# Altium schematic sheet 16 RGMII.SchDoc
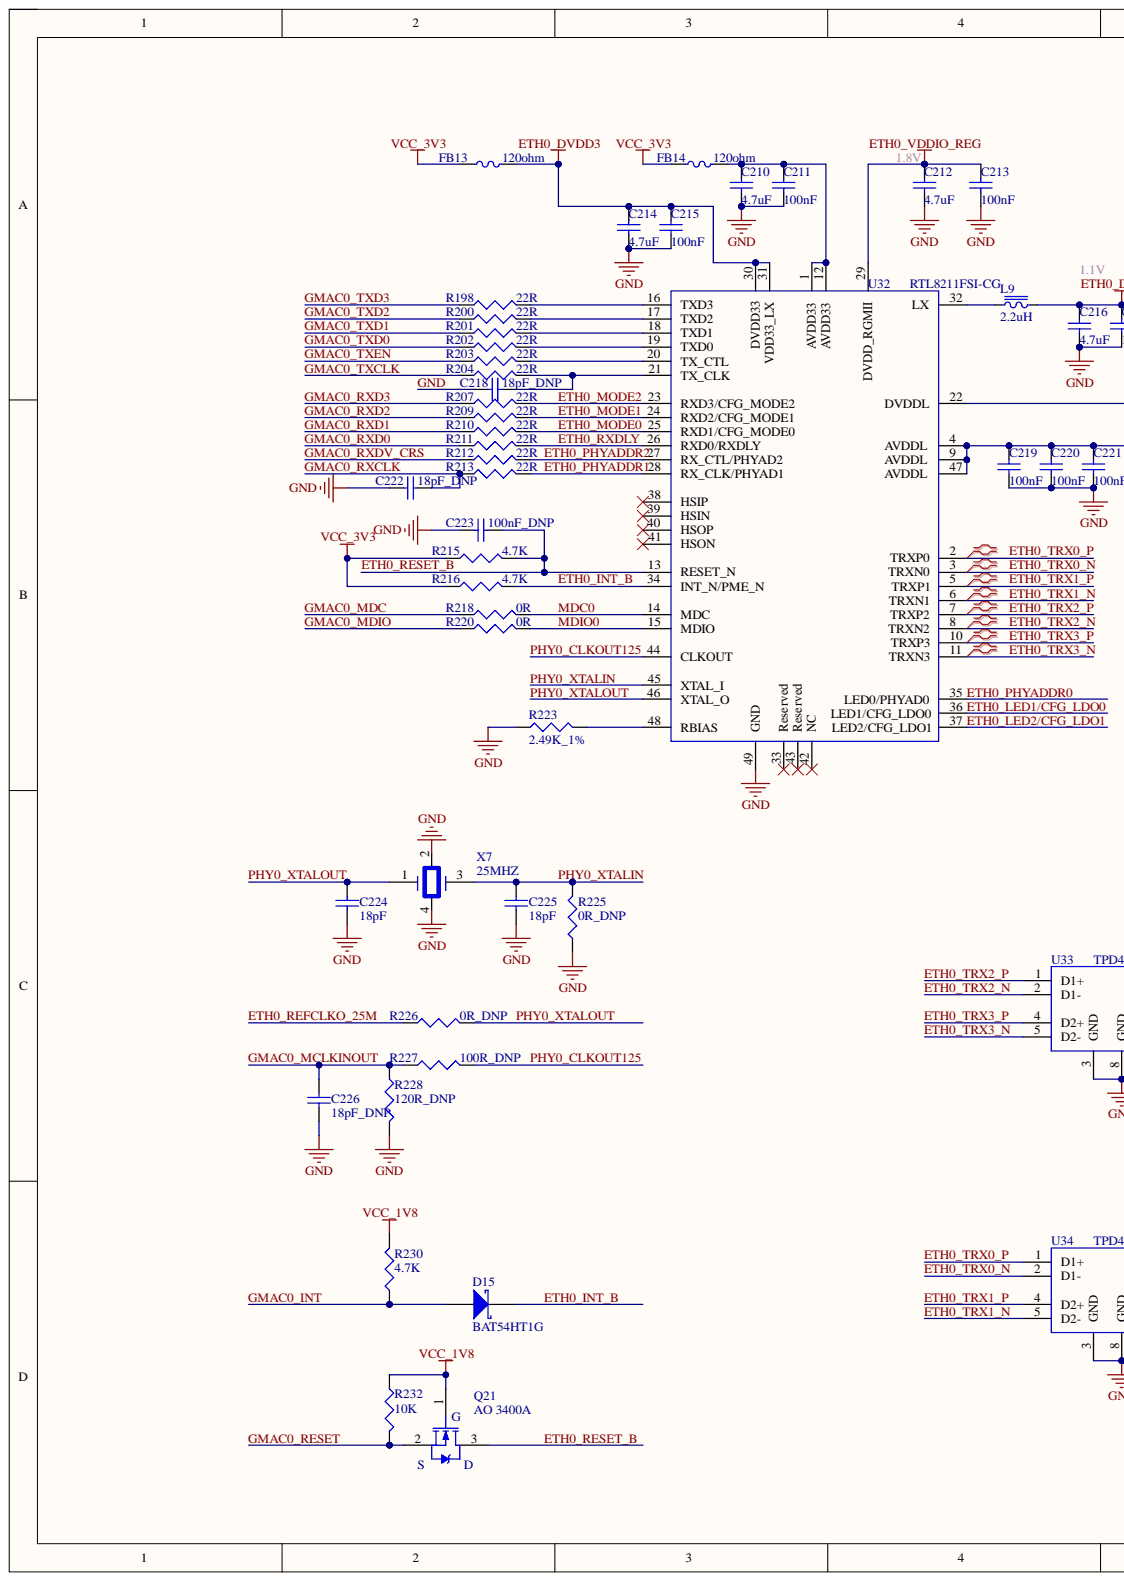
[diagram p0: 16 RGMII.SchDoc, left]
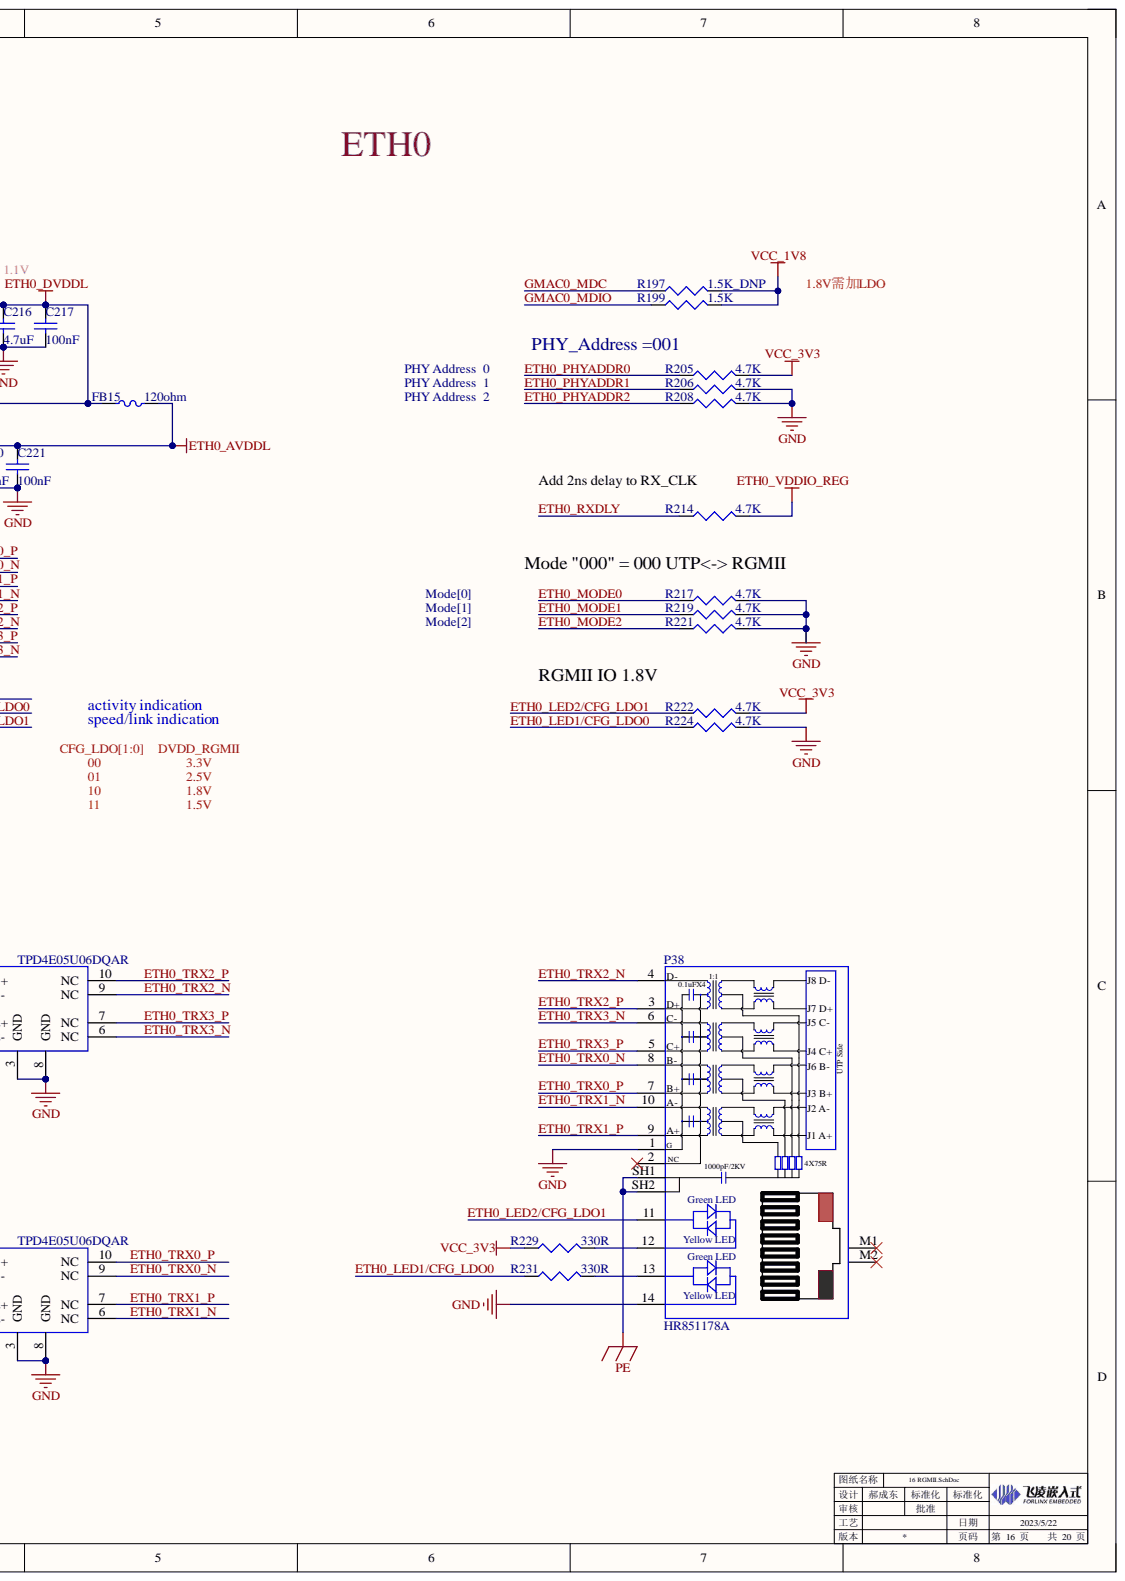
[diagram p1: 16 RGMII.SchDoc, right]

## Parts
C210  4.7uF  [0402C]
C211  100nF  [0402C]
C212  4.7uF  [0402C]
C213  100nF  [0402C]
C214  4.7uF  [0402C]
C215  100nF  [0402C]
C216  4.7uF  [0402C]
C217  100nF  [0402C]
C218  18pF_DNP  [0402C]
C219  100nF  [0402C]
C220  100nF  [0402C]
C221  100nF  [0402C]
C222  18pF_DNP  [0402C]
C223  100nF_DNP  [0402C]
C224  18pF  [0402C]
C225  18pF  [0402C]
C226  18pF_DNP  [0402C]
D15  BAT54HT1G  [SOD_323]
FB13  120ohm  [0603L]
FB14  120ohm  [0603L]
FB15  120ohm  [0603L]
L9  2.2uH  [WPN2016]
P38  HR851178A  [RJ45_Gigabit]
Q21  AO 3400A  [SOT_233_W]
R197  1.5K_DNP  [0402R]
R198  22R  [0402R]
R199  1.5K  [0402R]
R200  22R  [0402R]
R201  22R  [0402R]
R202  22R  [0402R]
R203  22R  [0402R]
R204  22R  [0402R]
R205  4.7K  [0402R]
R206  4.7K  [0402R]
R207  22R  [0402R]
R208  4.7K  [0402R]
R209  22R  [0402R]
R210  22R  [0402R]
R211  22R  [0402R]
R212  22R  [0402R]
R213  22R  [0402R]
R214  4.7K  [0402R]
R215  4.7K  [0402R]
R216  4.7K  [0402R]
R217  4.7K  [0402R]
R218  0R  [0402R]
R219  4.7K  [0402R]
R220  0R  [0402R]
R221  4.7K  [0402R]
R222  4.7K  [0402R]
R223  2.49K_1%  [0402R]
R224  4.7K  [0402R]
R225  0R_DNP  [0402R]
R226  0R_DNP  [0402R]
R227  100R_DNP  [0402R]
R228  120R_DNP  [0402R]
R229  330R  [0402R]
R230  4.7K  [0402R]
R231  330R  [0402R]
R232  10K  [0402R]
U32  RTL8211FSI-CG  [QFN48P_0.4BSC_6*6_H]
U33  TPD4E05U06DQAR  [USON-10]
U34  TPD4E05U06DQAR  [USON-10]
X7  25MHZ  [Crystals_3225]

## Nets
ETH0_AVDDL: C219.2 C220.2 C221.2 FB15.2 U32.4(AVDDL) U32.9(AVDDL) U32.47(AVDDL)
ETH0_DVDD3: C214.2 C215.2 FB13.2 U32.30(DVDD33) U32.31(VDD33_LX)
ETH0_DVDDL: C216.2 C217.2 FB15.1 L9.2 U32.22(DVDDL)
ETH0_VDDIO_REG: C212.2 C213.2 R214.2 U32.29(DVDD_RGMII)
PE: P38.SH1 P38.SH2
VCC_1V8: Q21.1 R197.2 R199.2 R230.2 R232.1
VCC_3V3: FB13.1 FB14.1 R205.2 R215.1 R216.1 R222.2 R229.1
ETH0_INT_B: D15.2 R216.2 U32.34(INT_N/PME_N)
ETH0_LED1/CFG_LDO0: R224.1 R231.1 U32.36(LED1/CFG_LDO0)
ETH0_LED2/CFG_LDO1: P38.11 R222.1 U32.37(LED2/CFG_LDO1)
ETH0_MODE0: R210.2 R217.1 U32.25(RXD1/CFG_MODE0)
ETH0_MODE1: R209.2 R219.1 U32.24(RXD2/CFG_MODE1)
ETH0_MODE2: R207.2 R221.1 U32.23(RXD3/CFG_MODE2)
ETH0_PHYADDR0: R205.1 U32.35(LED0/PHYAD0)
ETH0_PHYADDR1: R206.1 R213.2 U32.28(RX_CLK/PHYAD1)
ETH0_PHYADDR2: R208.1 R212.2 U32.27(RX_CTL/PHYAD2)
ETH0_REFCLKO_25M: R226.1
ETH0_RESET_B: C223.2 Q21.3 R215.2 U32.13(RESET_N)
ETH0_RXDLY: R211.2 R214.1 U32.26(RXD0/RXDLY)
ETH0_TRX0_N: P38.8(B-) U32.3(TRXN0) U34.2(D1-) U34.9(NC)
ETH0_TRX0_P: P38.7 U32.2(TRXP0) U34.1(D1+) U34.10(NC)
ETH0_TRX1_N: P38.10(A-) U32.6(TRXN1) U34.5(D2-) U34.6(NC)
ETH0_TRX1_P: P38.9 U32.5(TRXP1) U34.4(D2+) U34.7(NC)
ETH0_TRX2_N: P38.4 U32.8(TRXN2) U33.2(D1-) U33.9(NC)
ETH0_TRX2_P: P38.3(D+) U32.7(TRXP2) U33.1(D1+) U33.10(NC)
ETH0_TRX3_N: P38.6(C-) U32.11(TRXN3) U33.5(D2-) U33.6(NC)
ETH0_TRX3_P: P38.5(C+) U32.10(TRXP3) U33.4(D2+) U33.7(NC)
GMAC0_INT: D15.1 R230.1
GMAC0_MCLKINOUT: C226.2 R227.1 R228.1
GMAC0_MDC: R197.1 R218.1
GMAC0_MDIO: R199.1 R220.1
GMAC0_RESET: Q21.2 R232.2
GMAC0_RXCLK: C222.2 R213.1
GMAC0_RXD0: R211.1
GMAC0_RXD1: R210.1
GMAC0_RXD2: R209.1
GMAC0_RXD3: R207.1
GMAC0_RXDV_CRS: R212.1
GMAC0_TXCLK: R204.1
GMAC0_TXD0: R202.1
GMAC0_TXD1: R201.1
GMAC0_TXD2: R200.1
GMAC0_TXD3: R198.1
GMAC0_TXEN: R203.1
GND: C210.1 C211.1 C212.1 C213.1 C214.1 C215.1 C216.1 C217.1 C218.1 C219.1 C220.1 C221.1 C222.1 C223.1 C224.1 C225.1 C226.1 P38.1 P38.14 R206.2 R208.2 R217.2 R219.2 R221.2 R223.1 R224.2 R225.1 R228.2 U32.49(GND) U33.3(GND) U33.8(GND) U34.3(GND) U34.8(GND) X7.2 X7.4
MDC0: R218.2 U32.14(MDC)
MDIO0: R220.2 U32.15(MDIO)
PHY0_CLKOUT125: R227.2 U32.44(CLKOUT)
PHY0_XTALIN: C225.2 R225.2 U32.45(XTAL_I) X7.3
PHY0_XTALOUT: C224.2 R226.2 U32.46(XTAL_O) X7.1
NetC210_2: C210.2 C211.2 FB14.2 U32.1(AVDD33) U32.12(AVDD33)
NetC218_2: C218.2 R204.2 U32.21(TX_CLK)
NetL9_1: L9.1 U32.32(LX)
NetP38_12: P38.12 R229.2
NetP38_13: P38.13 R231.2
NetR198_2: R198.2 U32.16(TXD3)
NetR200_2: R200.2 U32.17(TXD2)
NetR201_2: R201.2 U32.18(TXD1)
NetR202_2: R202.2 U32.19(TXD0)
NetR203_2: R203.2 U32.20(TX_CTL)
NetR223_2: R223.2 U32.48(RBIAS)

Not connected: P38 2 M1 M2; U32 33(Reserved) 38(HSIP) 39(HSIN) 40(HSOP) 41(HSON) 42(NC) 43(Reserved)

## Library data of the parts
C210 C212 C214 C216 = 1110202032: Database Table Name: VALID$; 存货名称: 贴装叠层陶瓷电容; 序号: 616; 规格型号: 0402,4.7uF,10V,±10％,X5R; Component Kind: Standard; LatestRevisionDate: 17-Jul-2002; LatestRevisionNote: Re-released for DXP Platform.; Library Name: Database_AD Library.DbLib; PackageDescription: Radial Cap, Thru-Hole; 2 Leads; 0.3 in Pin Spacing; PackageReference: RAD-0.3; Published: 8-Jun-2000; Signal Integrity: Cap; Simulation: CAP; Value: 4.7uF; 存货编码: 1110202032; Publisher: Altium Limited
C211 C213 C215 C217 C219-C221 C223 = 1110202039: Database Table Name: VALID$; 存货名称: 贴装叠层陶瓷电容; 序号: 626; 规格型号: 0402,100nF,25V,±10％,X5R; Component Kind: Standard; LatestRevisionDate: 17-Jul-2002; LatestRevisionNote: Re-released for DXP Platform.; Library Name: Database_AD Library.DbLib; PackageDescription: Radial Cap, Thru-Hole; 2 Leads; 0.3 in Pin Spacing; PackageReference: RAD-0.3; Published: 8-Jun-2000; Signal Integrity: Cap; Simulation: CAP; 存货编码: 1110202039; Publisher: Altium Limited
  C211 C213 C215 C217 C219-C221: Value: 100nF
  C223: Value: 100nF_DNP
C218 C222 C224-C226 = 1110202008: Database Table Name: VALID$; 存货名称: 贴装叠层陶瓷电容; 序号: 578; 规格型号: 0402,18pF,50V,±5％,NPO; Component Kind: Standard; LatestRevisionDate: 17-Jul-2002; LatestRevisionNote: Re-released for DXP Platform.; Library Name: Database_AD Library.DbLib; PackageDescription: Radial Cap, Thru-Hole; 2 Leads; 0.3 in Pin Spacing; PackageReference: RAD-0.3; Published: 8-Jun-2000; Signal Integrity: Cap; Simulation: CAP; 存货编码: 1110202008; Publisher: Altium Limited
  C218 C222 C226: Value: 18pF_DNP
  C224 C225: Value: 18pF
D15 = 1110501028: Database Table Name: VALID$; 存货名称: 肖特基二极管; 序号: 2525; 规格型号: BAT54HT1G,SOD323; Code_JEDEC: DO-214-AA; Component Kind: Standard; LatestRevisionDate: 17-Jul-2002; LatestRevisionNote: Re-released for DXP Platform.; Library Name: Database_AD Library.DbLib; PackageDescription: DO-214-AA/SMB; 2 C-Bend Leads; Body 5.3 x 3.6 mm, inc. leads (LxW); PackageReference: SMB; Published: 8-Jun-2000; Signal Integrity: D Schottky; Simulation: SKYDIODE; Value: BAT54HT1G; 存货编码: 1110501028; Publisher: Altium Limited
FB13-FB15 = 1110304011: Database Table Name: VALID$; 主计量单位名称: 只; 存货名称: 磁珠; 安全库存: 12837.00; 序号: 1028; 旧编码: 010301092; 规格型号: 0603，120ohm; Component Kind: Standard; Library Name: Database_AD Library.DbLib; VALUE: 120ohm; 启用日期: 2015/3/11; 存货编码: 1110304011
L9 = 1110301085: Database Table Name: VALID$; 存货名称: 绕线电感; 规格型号: 2.2uH,2*1.6*1mm,2.15A,平行焊盘,非屏蔽,WPN201610H2R2MT; Component Kind: Standard; LatestRevisionDate: 17-Jul-2002; LatestRevisionNote: Re-released for DXP Platform.; Library Name: Database_AD Library.DbLib; PackageDescription: Axial Device, Thru-Hole; 2 Leads; 0.9 in Pin Spacing; PackageReference: AXIAL-0.9; Published: 24-Mar-1999; Signal Integrity: Inductor Iron; Simulation: INDUCTOR; Value: 2.2uH; 存货编码: 1110301085; Publisher: Altium Limited
P38 = 1120202071: Database Table Name: VALID$; 主计量单位名称: 个; 存货名称: 网口座; 安全库存: 672.00; 序号: 1656; 旧编码: 010402406; 规格型号: HR851178A RJ45 1000M 带灯 有变压器 无外围弹片; Component Kind: Standard; Library Name: Database_AD Library.DbLib; VALUE: HR851178A; 启用日期: 2018/1/5; 存货编码: 1120202071
Q21 = 1110505027: Database Table Name: VALID$; Note: Pin 2 is electrically connected to pin 4.; 存货名称: 场效应管; 描述栏信息: 替换1110505011; 规格型号: AO3400A,SOT23,N沟道; Component Kind: Standard; DatasheetVersion: PD-9.814; LatestRevisionDate: 17-Jul-2002; LatestRevisionNote: Re-released for DXP Platform.; Library Name: Database_AD Library.DbLib; PackageDescription: TO, Thru-Hole, Vertical, Heatsink Mounted; 3 In-Line Leads; Pitch 2.54 mm; PackageReference: TO-220AB; PackageVersion: Feb-1997; Published: 8-Jun-2000; Simulation: IRF1010; VALUE: AO 3400A; 存货编码: 1110505027; Publisher: Altium Limited
R197 R199 = 1110102021: Database Table Name: VALID$; 存货名称: 贴装电阻; 序号: 31; 规格型号: 0402,1.5K,±5％; Component Kind: Standard; Library Name: Database_AD Library.DbLib; Signal Integrity: Res; Simulation: RESISTOR; 存货编码: 1110102021
  R197: Value: 1.5K_DNP
  R199: Value: 1.5K
R198 R200-R204 R207 R209-R213 = 1110102028: Database Table Name: VALID$; 存货名称: 贴装电阻; 序号: 41; 规格型号: 0402,22Ω,±5％; Component Kind: Standard; Library Name: Database_AD Library.DbLib; Signal Integrity: Res; Simulation: RESISTOR; Value: 22R; 存货编码: 1110102028
R205 R206 R208 R214-R217 R219 R221 R222 R224 R230 = 1110102003: Database Table Name: VALID$; 存货名称: 贴装电阻; 序号: 12; 规格型号: 0402,4.7K,±5％; Component Kind: Standard; Library Name: Database_AD Library.DbLib; Signal Integrity: Res; Simulation: RESISTOR; Value: 4.7K; 存货编码: 1110102003
R218 R220 R225 R226 = 1110102001: Database Table Name: VALID$; 存货名称: 贴装电阻; 序号: 10; 规格型号: 0402,0Ω,±5％; Component Kind: Standard; Library Name: Database_AD Library.DbLib; Signal Integrity: Res; Simulation: RESISTOR; 存货编码: 1110102001
  R218 R220: Value: 0R
  R225 R226: Value: 0R_DNP
R223 = 1110102182: Database Table Name: VALID$; 存货名称: 贴装电阻; 描述栏信息: 厚声; 规格型号: 0402,2.49K,±1％; Component Kind: Standard; Library Name: Database_AD Library.DbLib; Signal Integrity: Res; Simulation: RESISTOR; Value: 2.49K_1%; 存货编码: 1110102182
R227 = 1110102052: Database Table Name: VALID$; 存货名称: 贴装电阻; 序号: 66; 规格型号: 0402,100Ω,±5％; Component Kind: Standard; Library Name: Database_AD Library.DbLib; Signal Integrity: Res; Simulation: RESISTOR; Value: 100R_DNP; 存货编码: 1110102052
R228 = 1110102071: Database Table Name: VALID$; 存货名称: 贴装电阻; 序号: 101; 规格型号: 0402,120Ω,±5％; Component Kind: Standard; Library Name: Database_AD Library.DbLib; Signal Integrity: Res; Simulation: RESISTOR; Value: 120R_DNP; 存货编码: 1110102071
R229 R231 = 1110102043: Database Table Name: VALID$; 存货名称: 贴装电阻; 序号: 56; 规格型号: 0402,330Ω,±5％; Component Kind: Standard; Library Name: Database_AD Library.DbLib; Signal Integrity: Res; Simulation: RESISTOR; Value: 330R; 存货编码: 1110102043
R232 = 1110102007: Database Table Name: VALID$; 存货名称: 贴装电阻; 序号: 17; 规格型号: 0402,10K,±5％; Component Kind: Standard; Library Name: Database_AD Library.DbLib; Signal Integrity: Res; Simulation: RESISTOR; Value: 10K; 存货编码: 1110102007
U32 = 1110405363: Database Table Name: VALID$; 存货名称: 常规IC; 规格型号: RTL8211FSI-CG,QFN48; Component Kind: Standard; Library Name: Database_AD Library.DbLib; Value: RTL8211FSI-CG; 存货编码: 1110405363
U33 U34 = 1110503037: Database Table Name: VALID$; 存货名称: TVS管; 规格型号: TPD4E05U06DQAR,USON; Component Kind: Standard; Library Name: Database_AD Library.DbLib; Value: TPD4E05U06DQAR; 存货编码: 1110503037
X7 = 1110601037: Database Table Name: VALID$; 存货名称: 贴装晶振; 序号: 3392; 规格型号: 无源,25MHZ,4P,3.2*2.5mm,±20ppm,20pf; Component Kind: Standard; Library Name: Database_AD Library.DbLib; Value: 25MHZ; 存货编码: 1110601037
